# Altium schematic sheet POWER_3V3.SchDoc(POWER_3V3)
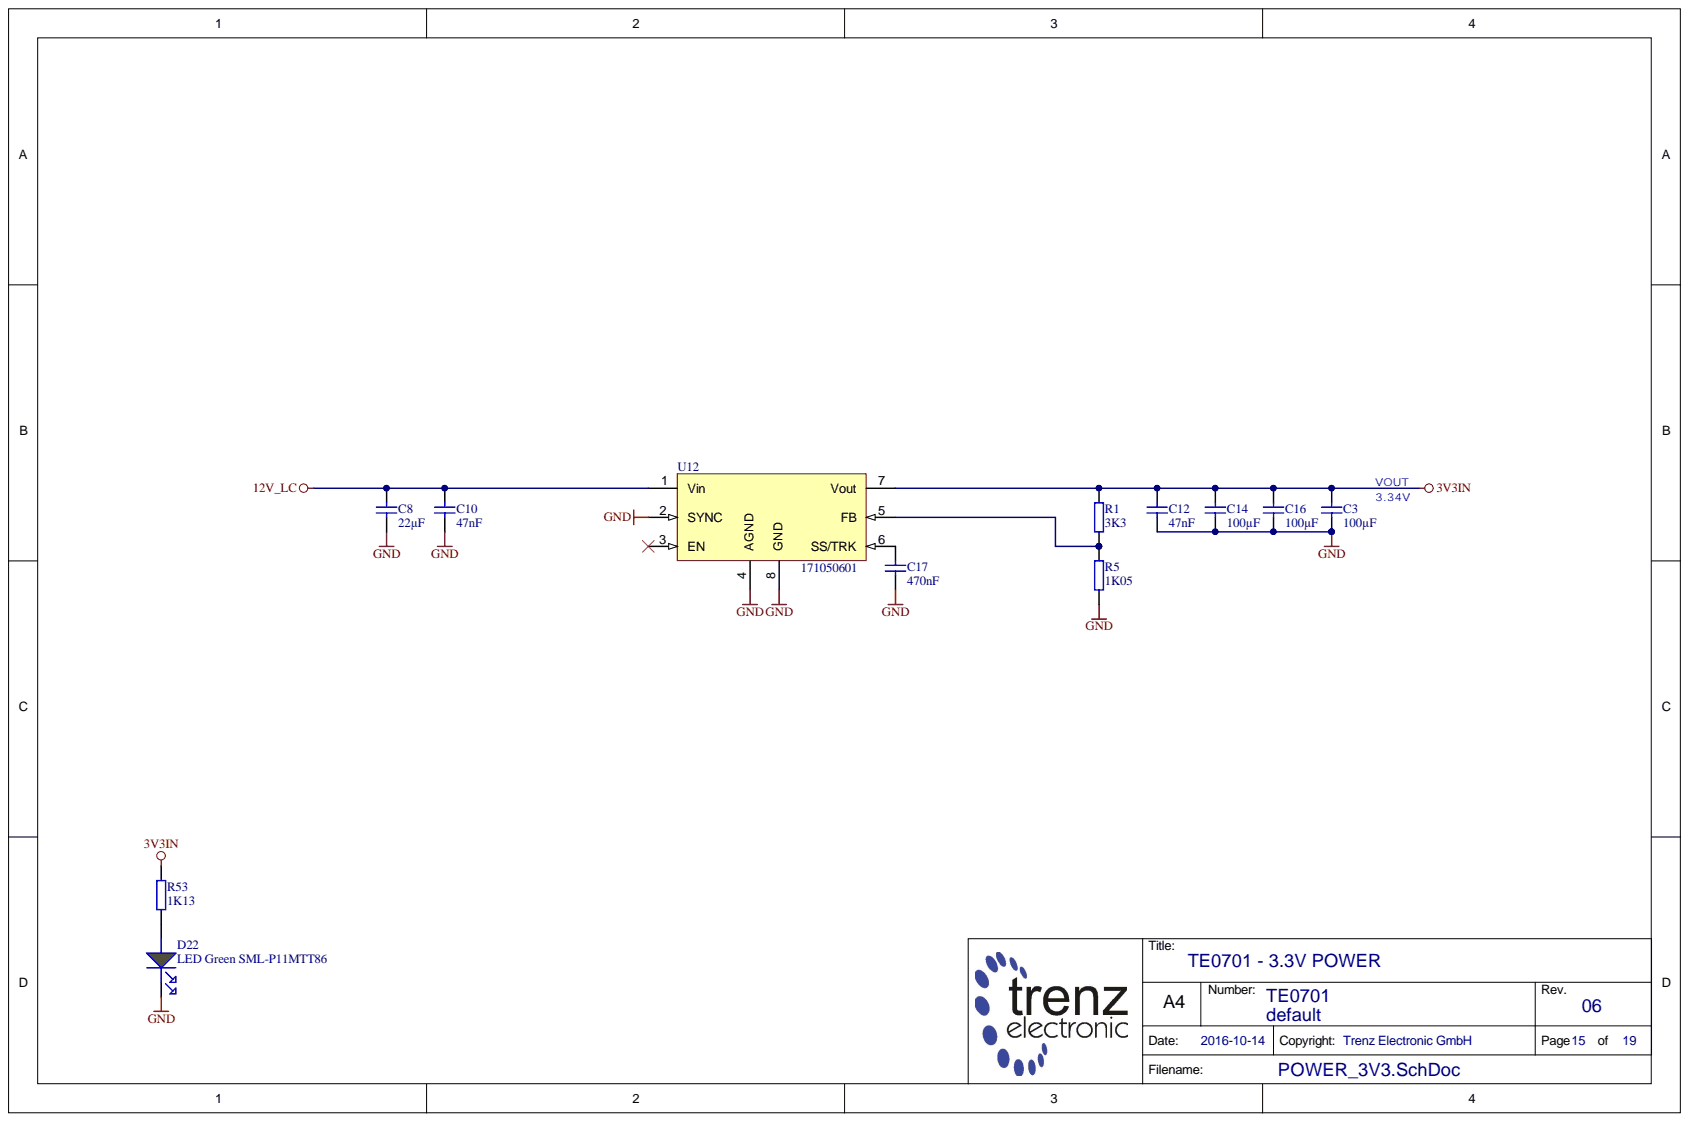
[diagram p0: POWER_3V3.SchDoc]

## Parts
C3  100µF  [CAPC3216X180N]
C8  22µF  [CAPC3216X180N]
C10  47nF  [CAPC1005X55N]
C12  47nF  [CAPC1005X55N]
C14  100µF  [CAPC3216X180N]
C16  100µF  [CAPC3216X180N]
C17  470nF  [CAPC1005X55N]
D22  LED Green SML-P11MTT86  Database Table Name: LED  [LEDC1006X25N_GREEN]
R1  3K3  [RESC1005X40N]
R5  1K05  [RESC1005X40N]
R53  1K13  [RESC1005X40N]
U12  171050601  [7TO-PMOD]

## Nets
3V3IN: C3.1 C12.2 C14.1 C16.1 R1.1 R53.1 U12.7(Vout)
12V_LC: C8.2 C10.2 U12.1(Vin)
GND: C3.2 C8.1 C10.1 C12.1 C14.2 C16.2 C17.2 D22.K R5.2 U12.2(SYNC) U12.4(AGND) U12.8(GND)
NetC17_1: C17.1 U12.6(SS/TRK)
NetD22_A: D22.A R53.2
NetR1_2: R1.2 R5.1 U12.5(FB)

Not connected: U12 3(EN)

## Library data of the parts
C3 C14 C16 = 23116: KON 100µF 6,3V X5R SMD 20%; Database Table Name: C_3216_1206; Mixed: SMT; Preferred: Yes; Value: 100u; Voltage: 6.3V; AN: 23116; Case: 3216 (1206); Component Kind: Standard; Library Name: TE.DbLib; Technology: X5R; Tolerance: 20%
C8 = 24886: KON 22µF X5R 25V SMD 10%; Database Table Name: C_3216_1206; Mixed: SMT; Preferred: Yes; Value: 22u; AN: 24886; Case: 3216 (1206); Component Kind: Standard; Library Name: TE.DbLib; Tolerance: 10%; Voltage: 25V
C10 C12 = 24684: KON 47nF 50V X7R SMD 10%; Database Table Name: C_1005_0402; Mixed: SMT; Value: 47n; AN: 24684; Case: 1005 (0402); Component Kind: Standard; Library Name: TE.DbLib; Technology: X7R; Tolerance: 10%; Voltage: 50V
C17 = 23659: KON 470nF 6,3V X5R SMD; Database Table Name: C_1005_0402; Mixed: SMT; Preferred: Yes; Value: 470n; Voltage: 6.3V; AN: 23659; Case: 1005 (0402); Component Kind: Standard; Library Name: TE.DbLib; Technology: X5R
D22 = 24211: LED Grün SML-P11MTT86 SMD; Mixed: SMT; Preferred: Yes; AN: 24211; Case: 1005 (0402); Component Kind: Standard; H-ART: SML-P11MTT86; Library Name: TE.DbLib; HelpURL: B:\AD\adlib\datasheet\SML-P1
R1 = 22763: WID SMD 3K3 1% 0,063W; Database Table Name: R_1005_0402; Mixed: SMT; Preferred: Yes; Value: 3.3K; AN: 22763; Case: 1005 (0402); Component Kind: Standard; Library Name: TE.DbLib; Technology: TK100; Tolerance: 1%
R5 = 25956: WID SMD 1K05 1% 0,063W; Database Table Name: R_1005_0402; Mixed: SMT; Value: 1.05K; AN: 25956; Case: 1005 (0402); Component Kind: Standard; Library Name: TE.DbLib; Technology: TK100; Tolerance: 1%
R53 = 23160: WID SMD 1K13 1% 0,063W; Database Table Name: R_1005_0402; Mixed: SMT; Preferred: Yes; Value: 1.13K; AN: 23160; Case: 1005 (0402); Component Kind: Standard; Library Name: TE.DbLib; Technology: TK100; Tolerance: 1%
U12 = 26051: Database Table Name: DCDC; Mixed: SMT; AN: 26051; Case: TO263-7EP; Component Kind: Standard; Description: 171050601; H-ART: 171050601; Library Name: TE.DbLib; HelpURL: B:\AD\adlib\datasheet\171050601
